# Altium schematic sheet Training_Stand.SchDoc
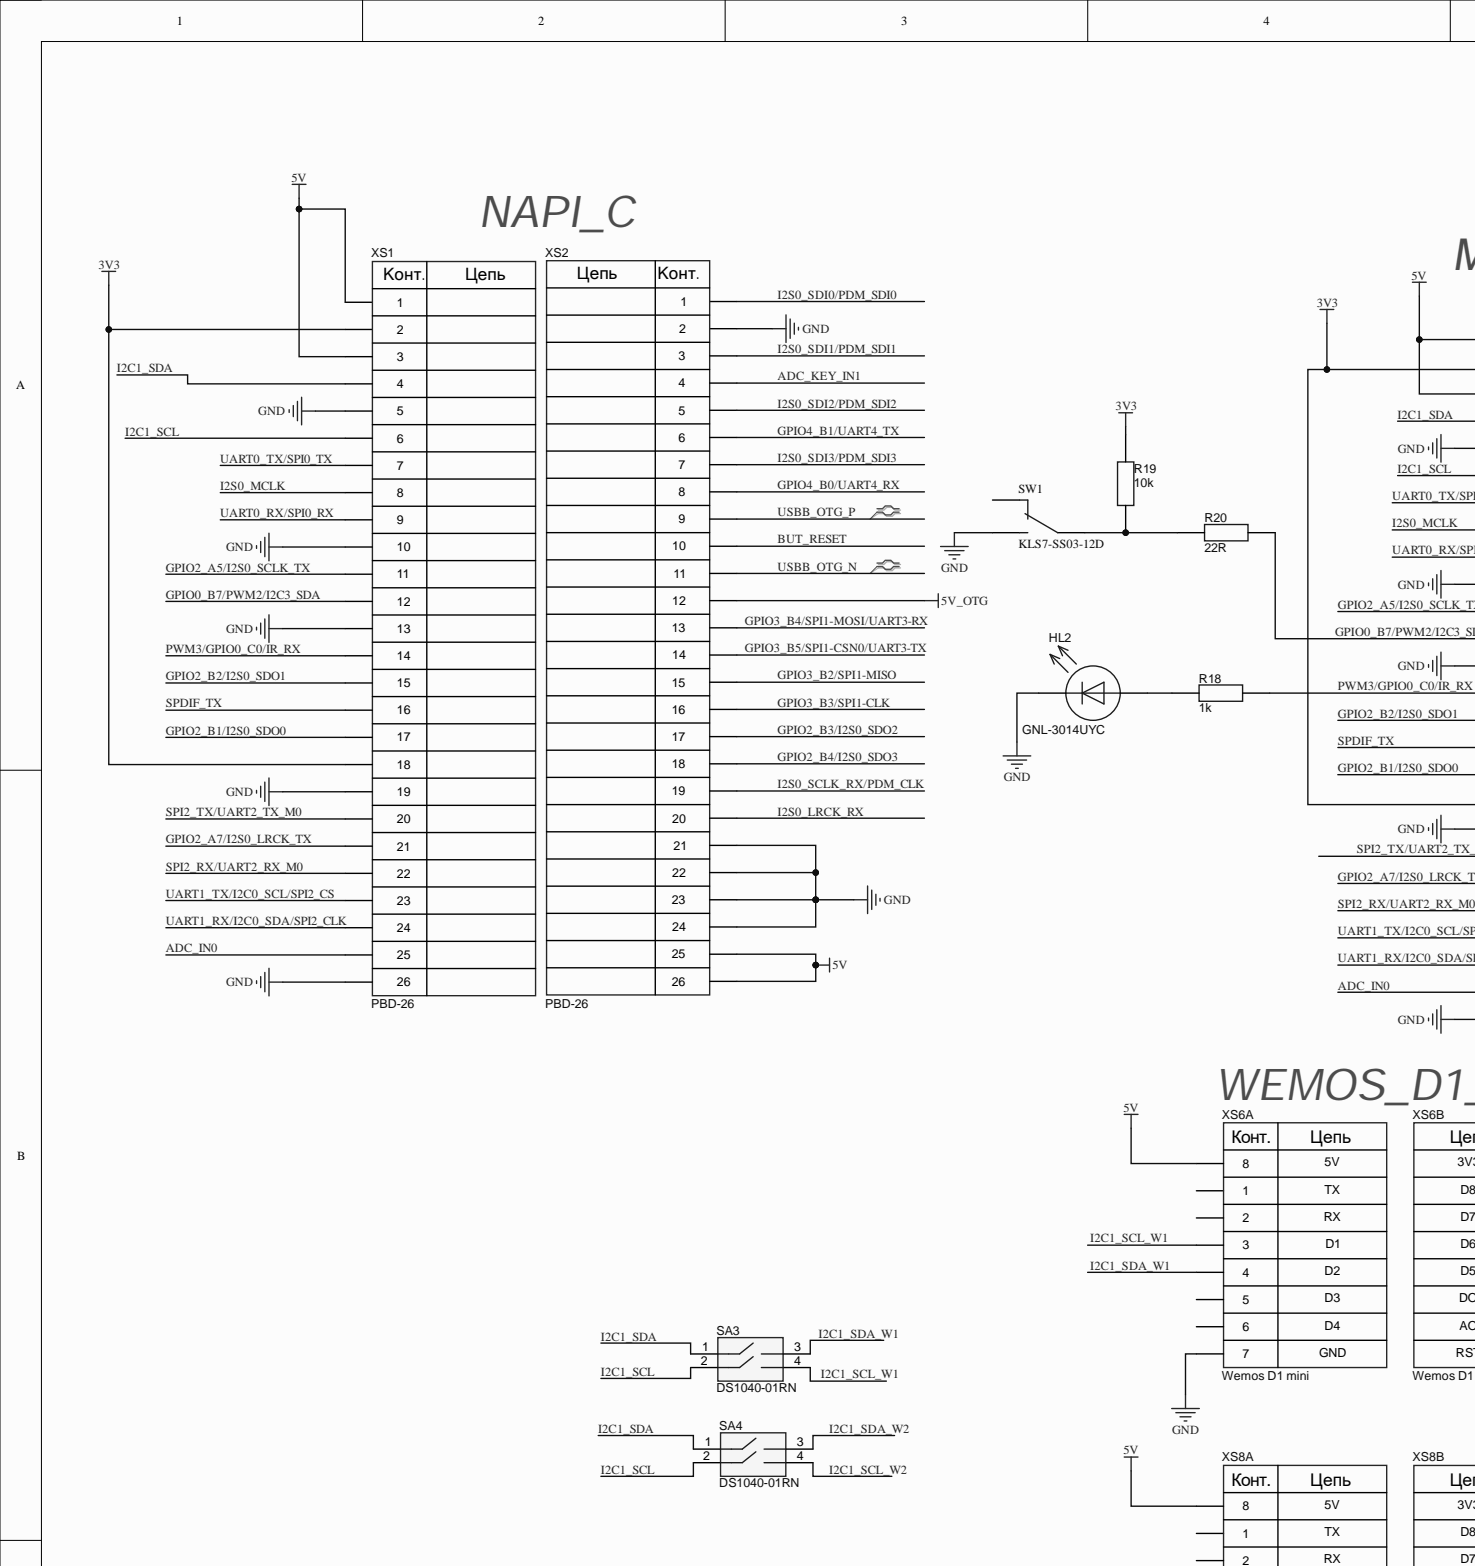
[diagram p0: Training_Stand.SchDoc, top left]
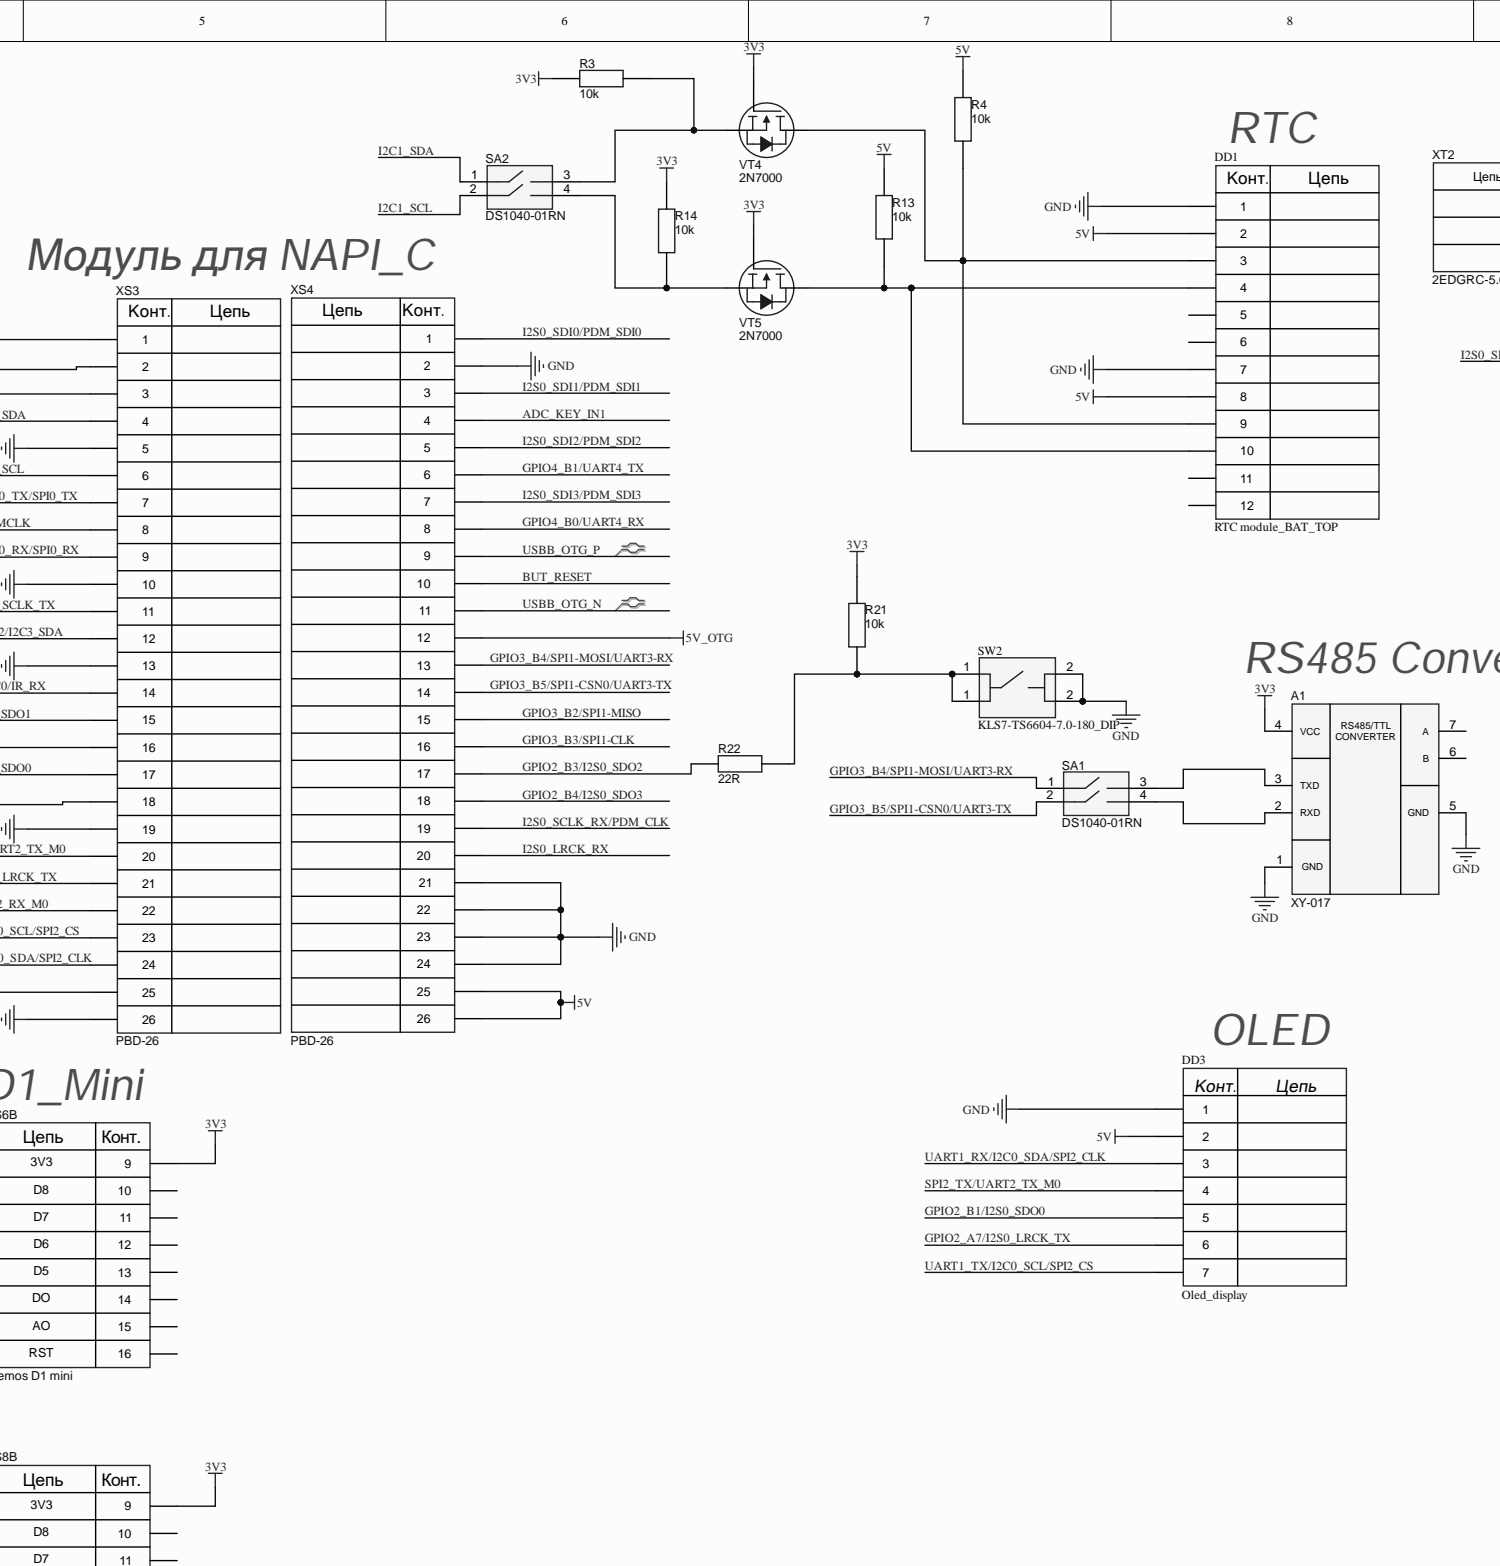
[diagram p1: Training_Stand.SchDoc, top centre]
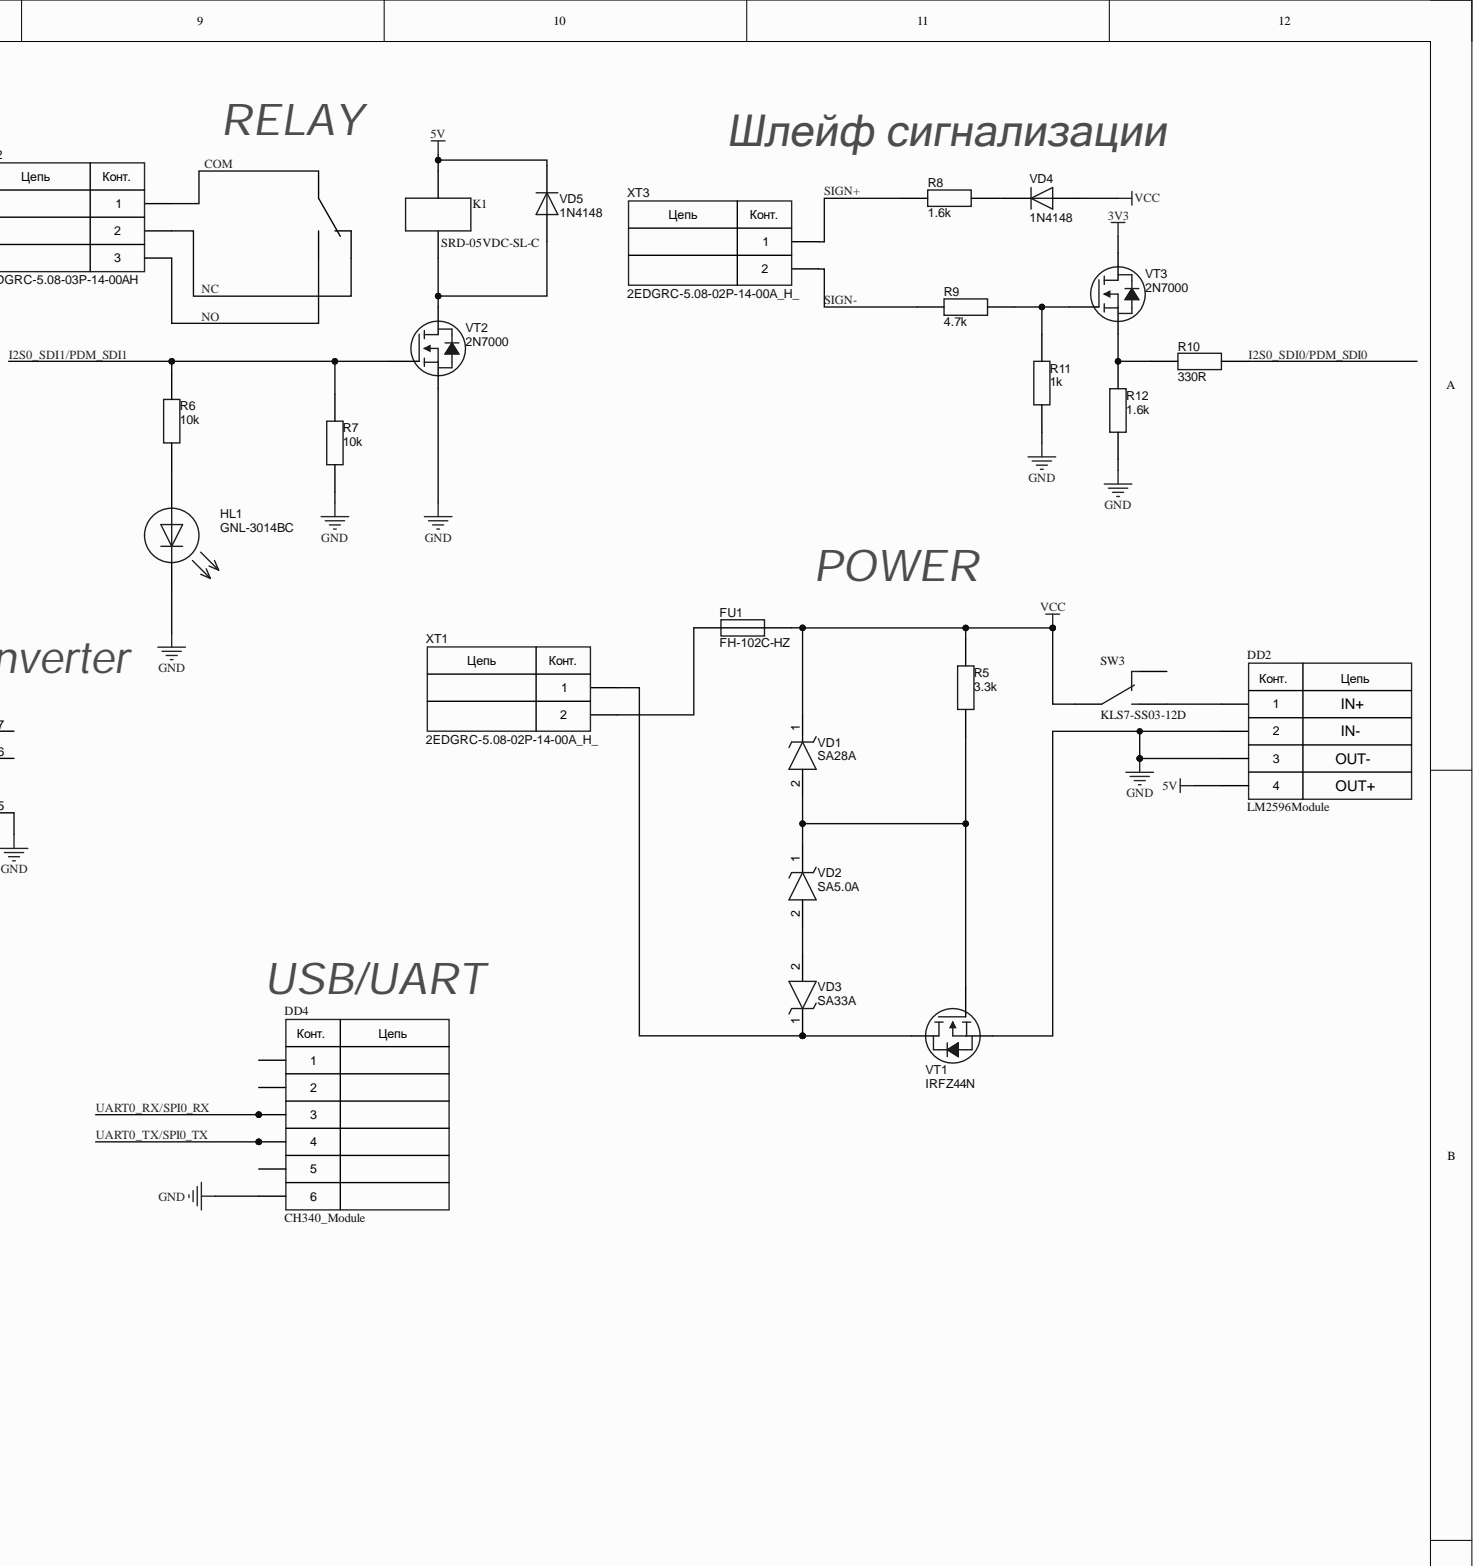
[diagram p2: Training_Stand.SchDoc, top right]
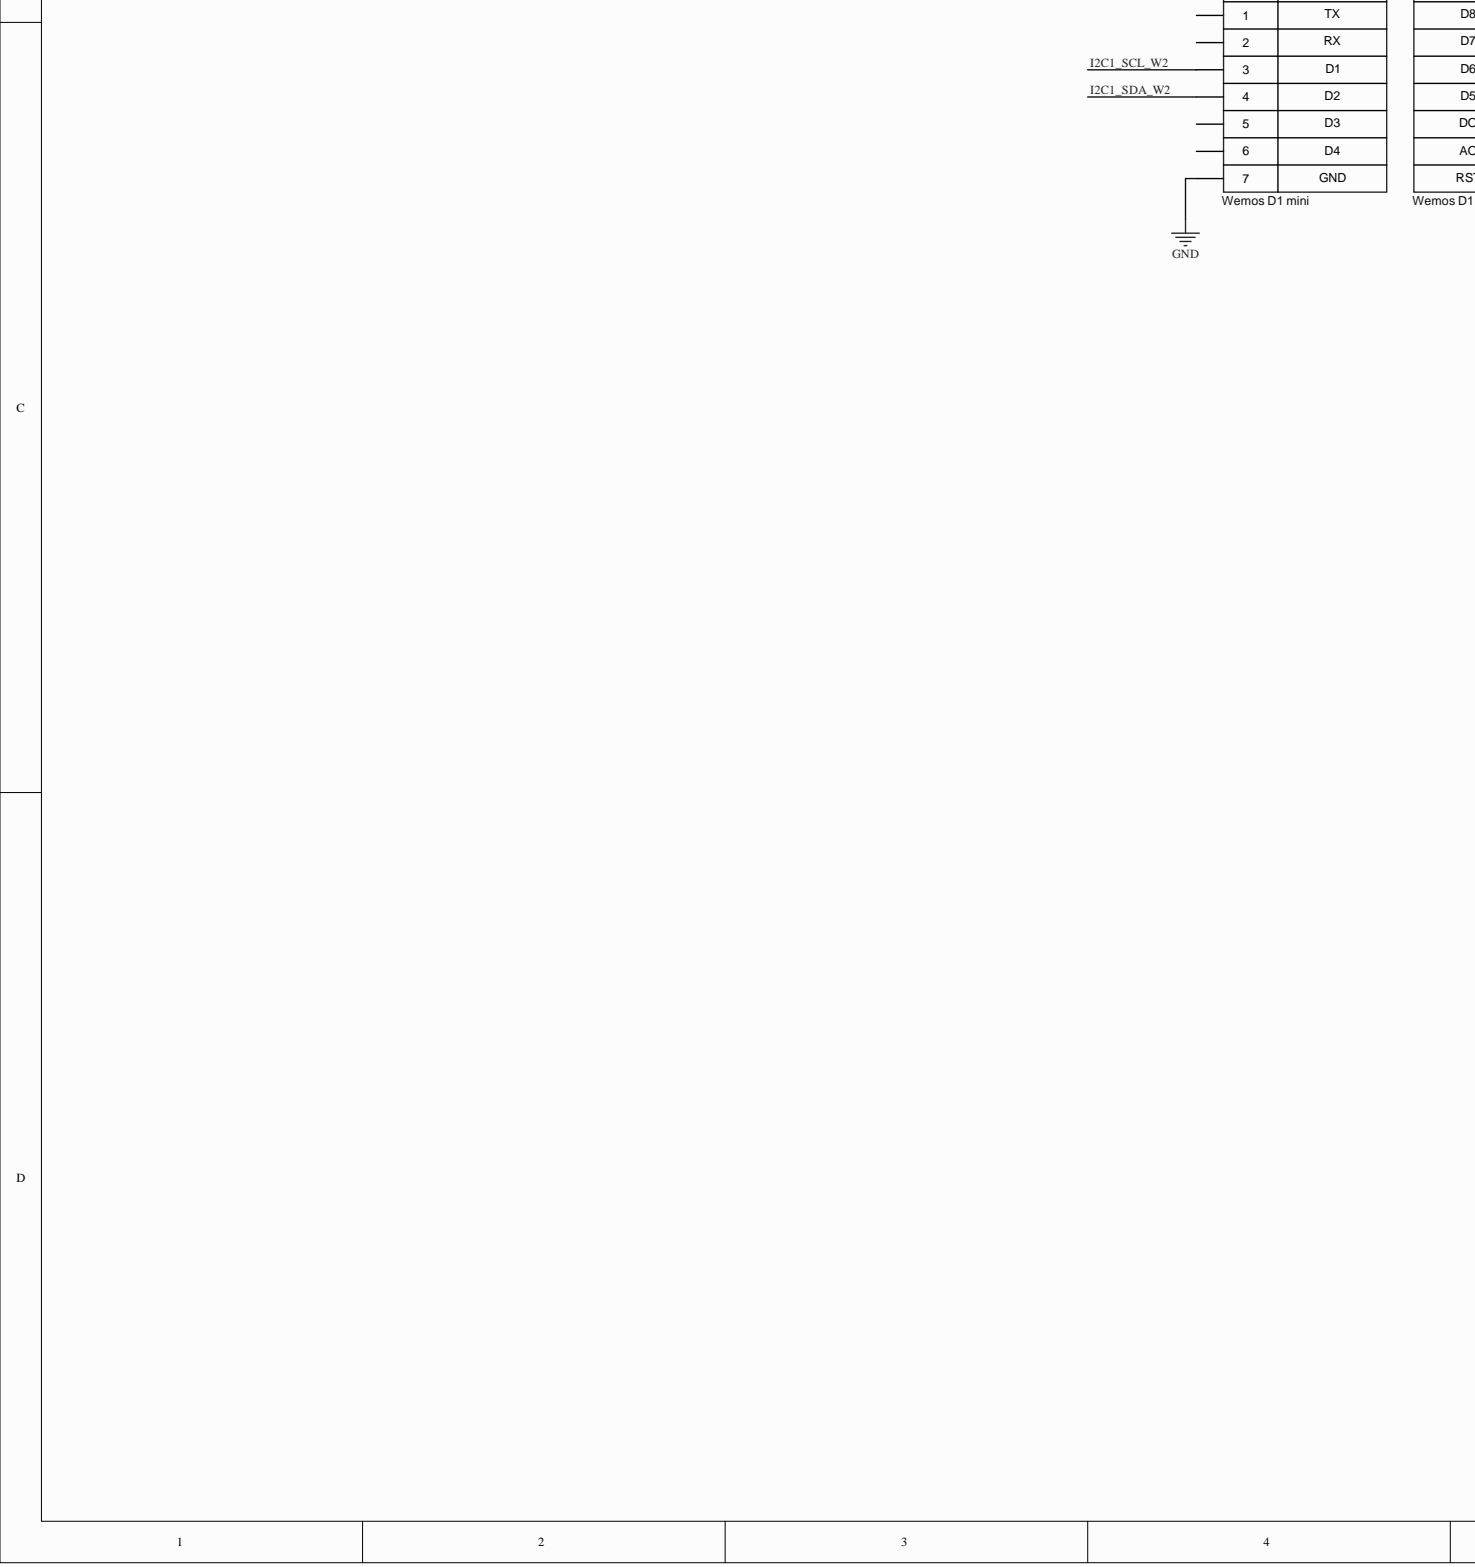
[diagram p3: Training_Stand.SchDoc, bottom left]
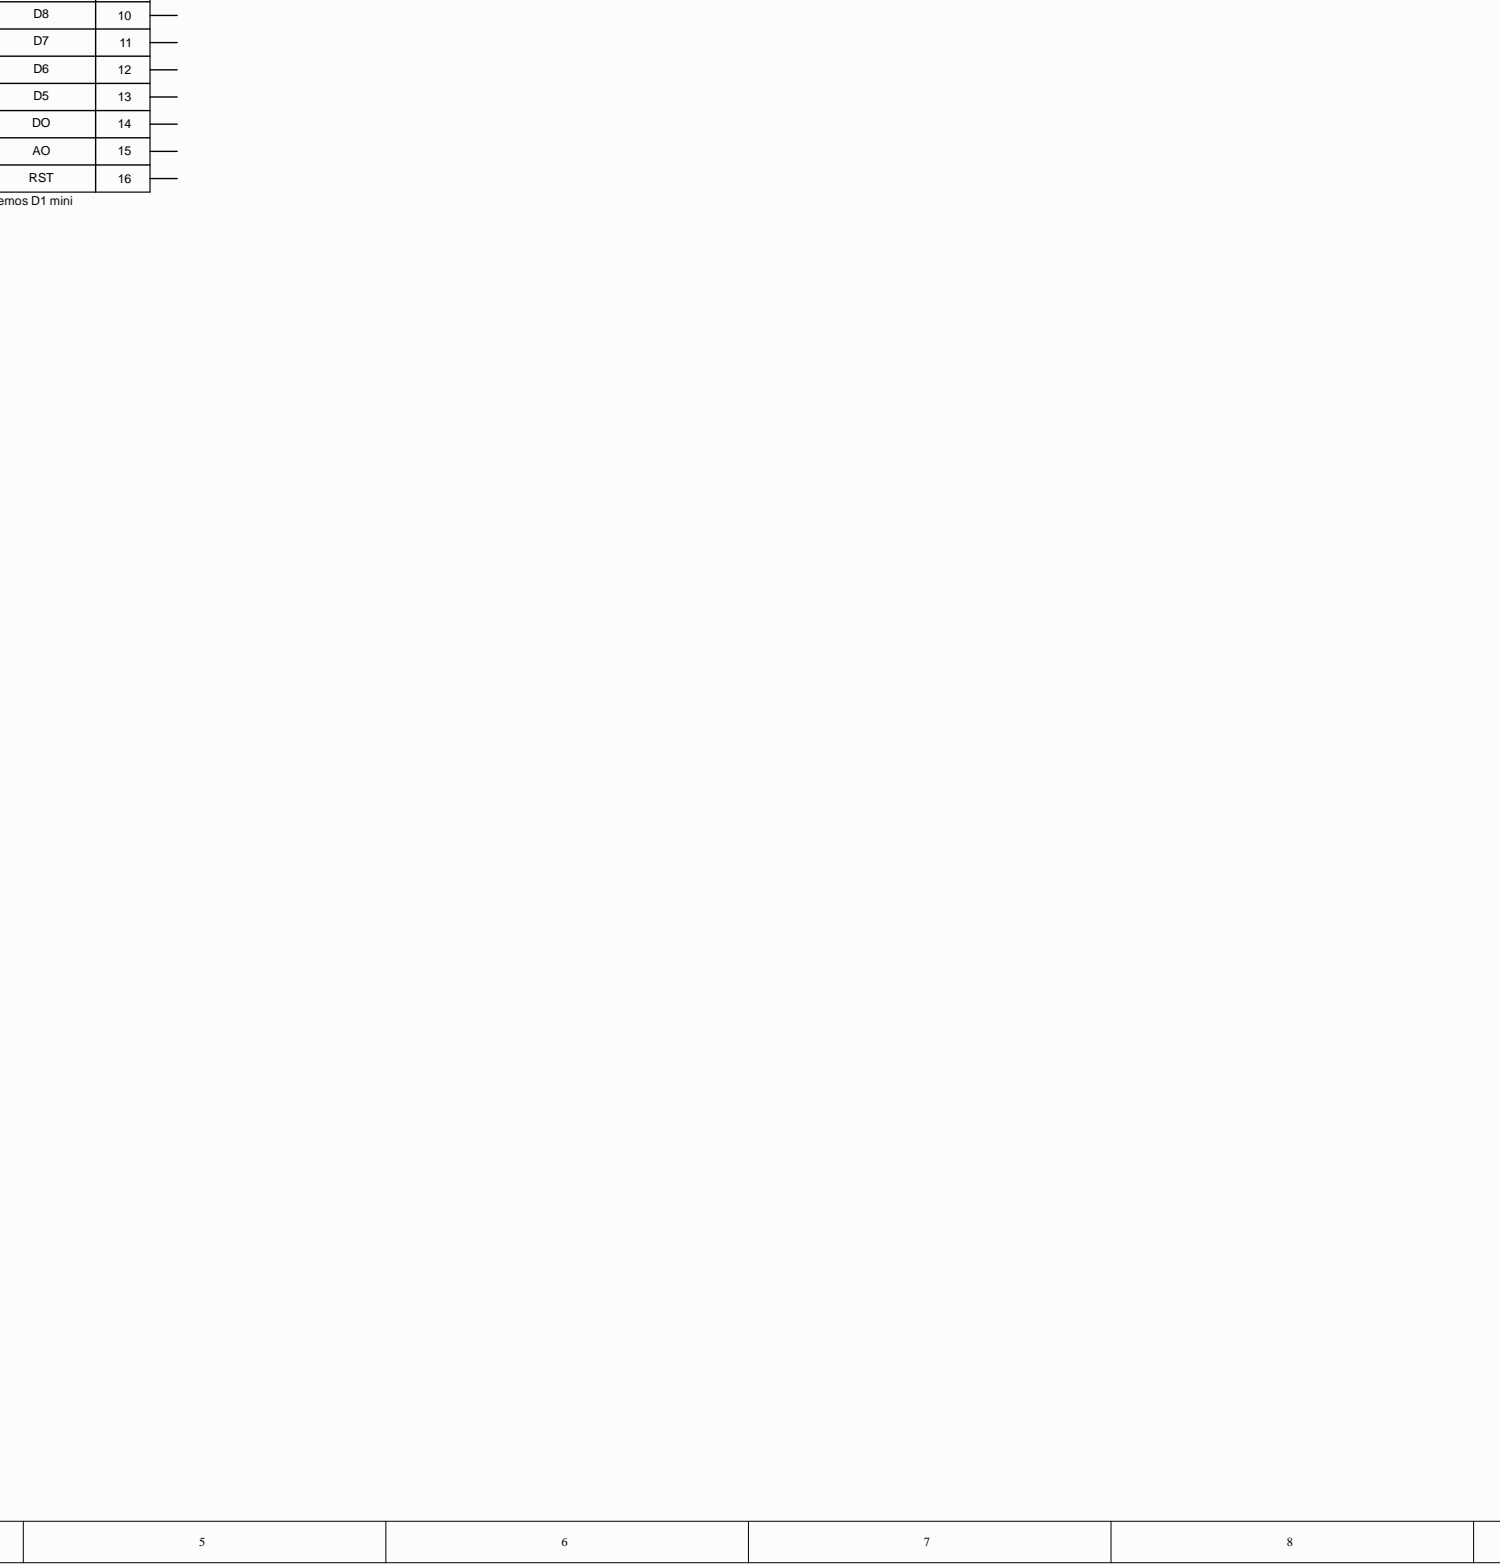
[diagram p4: Training_Stand.SchDoc, bottom centre]
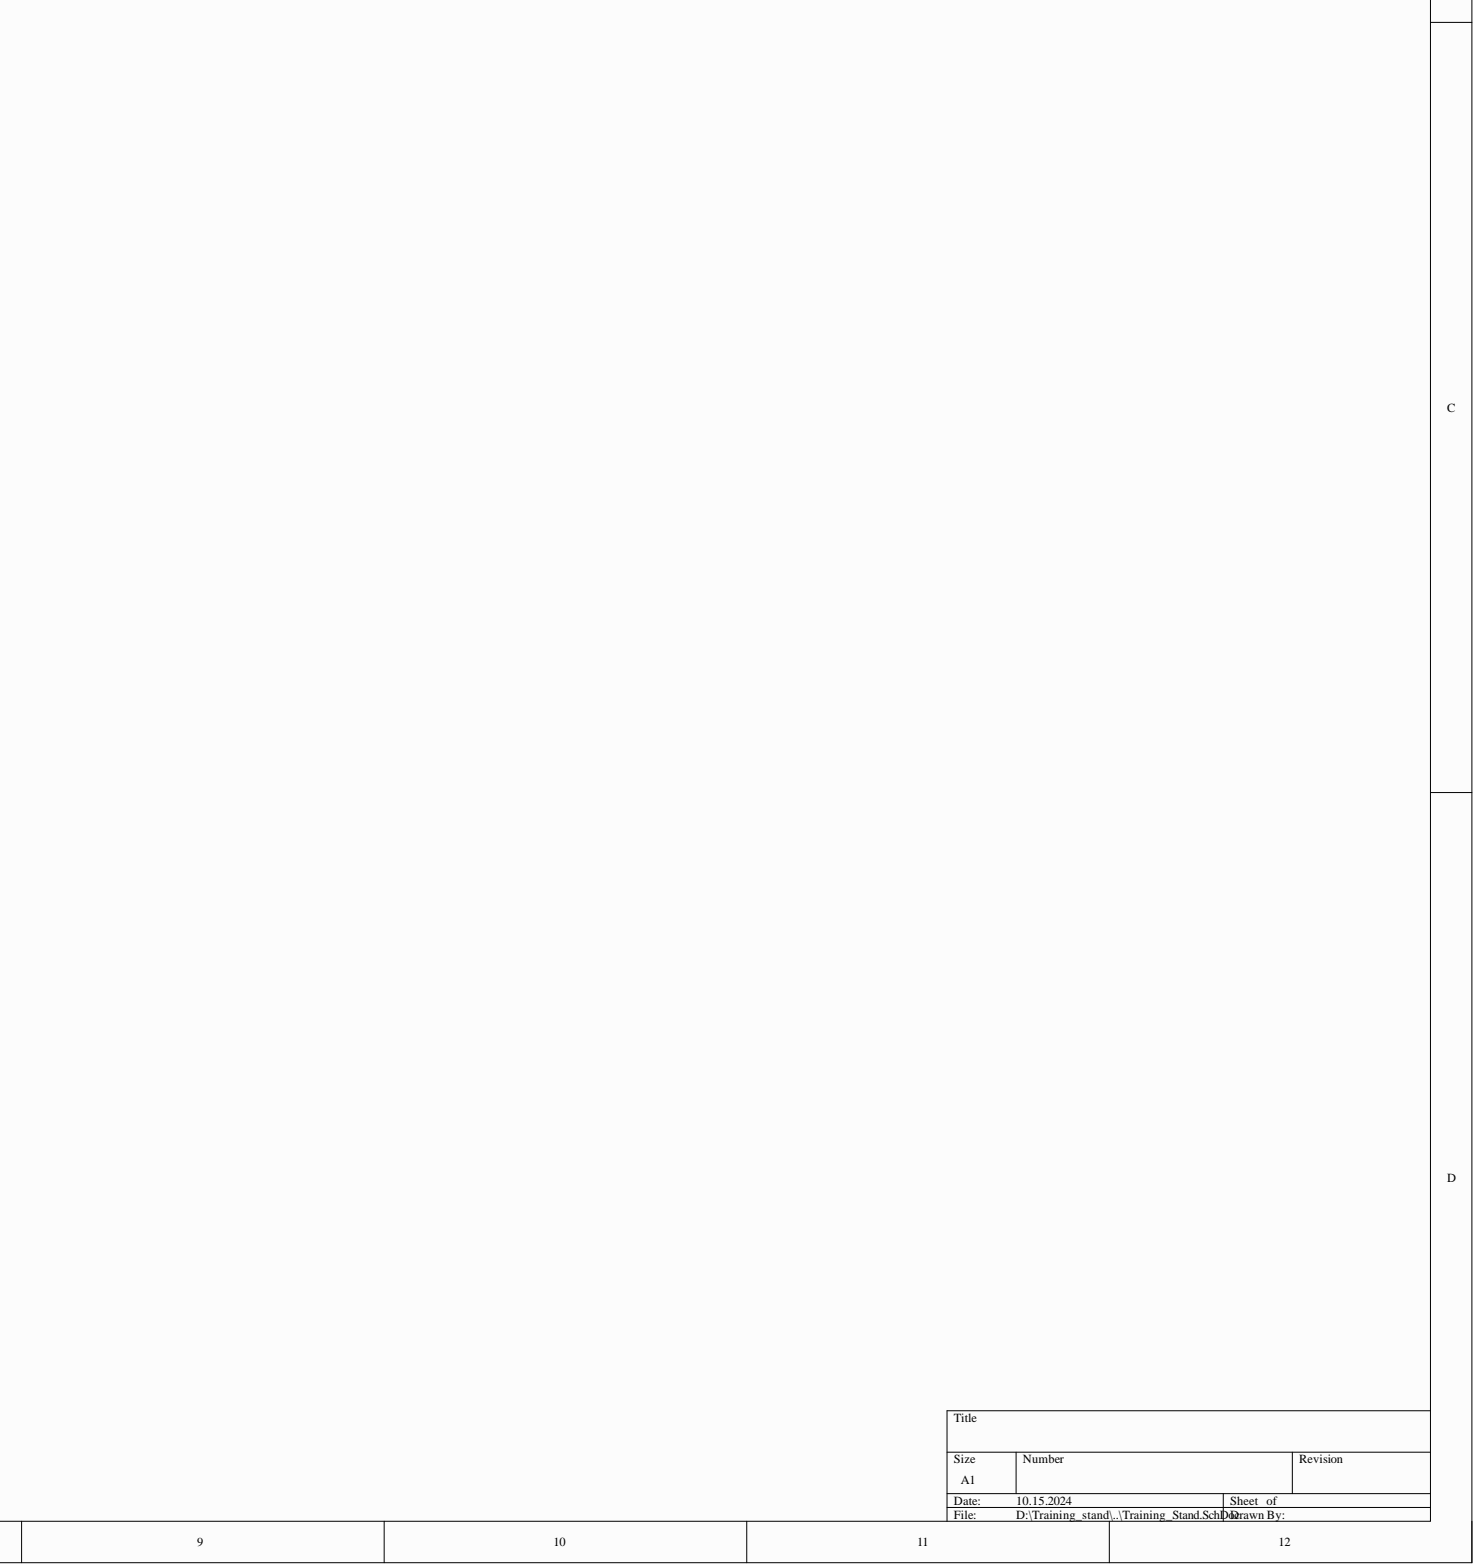
[diagram p5: Training_Stand.SchDoc, bottom right]

## Parts
A1  XY-017  [XY-017 RS485/TTL]
DD1  RTC module_BAT_TOP  [RTC module_BAT TOP]
DD2  LM2596Module  [LM2696 module]
DD3  Oled_display  [OLED_ARDUINO_SPI]
DD4  CH340_Module  [CH340 module]
FU1  FH-102C-HZ  [FH-102]
HL1  GNL-3014BC  [LED_Blue_dip]
HL2  GNL-3014UYC  [LED_Yellow_dip]
K1  SRD-05VDC-SL-C  Voltage: 5V  [SRD-05VDC-SL-C]
R3  10k  [RES_DIP]
R4  10k  [RES_DIP]
R5  3.3k  [RES_DIP]
R6  10k  [RES_DIP]
R7  10k  [RES_DIP]
R8  1.6k  [RES_DIP]
R9  4.7k  [RES_DIP]
R10  330R  [RES_DIP]
R11  1k  [RES_DIP]
R12  1.6k  [RES_DIP]
R13  10k  [RES_DIP]
R14  10k  [RES_DIP]
R18  1k  [RES_DIP]
R19  10k  [RES_DIP]
R20  22R  [RES_DIP]
R21  10k  [RES_DIP]
R22  22R  [RES_DIP]
SA1  DS1040-01RN  [DS1040-02N]
SA2  DS1040-01RN  [DS1040-02N]
SA3  DS1040-01RN  [DS1040-02N]
SA4  DS1040-01RN  [DS1040-02N]
SW1  KLS7-SS03-12D  [KLS7-SS03-12D02]
SW2  KLS7-TS6604-7.0-180_DIP  [Tact_SW_7.0]
SW3  KLS7-SS03-12D  [KLS7-SS03-12D02]
VD1  SA28A  [SA28A]
VD2  SA5.0A  [SA5.0]
VD3  SA33A  [SA33A]
VD4  1N4148  [DO-35(1n4148)]
VD5  1N4148  [DO-35(1n4148)]
VT1  IRFZ44N  [TO-220]
VT2  2N7000  [TO-92]
VT3  2N7000  [TO-92]
VT4  2N7000  [TO-92]
VT5  2N7000  [TO-92]
XS1  PBD-26  [PBD-26]
XS2  PBD-26  [PBD-26]
XS3  PBD-26  [PBD-26]
XS4  PBD-26  [PBD-26]
XS6  Wemos D1 mini  [Wemos D1 relay v2_PBD]  (parts A,B)
XS8  Wemos D1 mini  [Wemos D1 relay v2_PBD]  (parts A,B)
XT1  2EDGRC-5.08-02P-14-00A_H_  [2EDGRC-5.0-02P-14-00AH]
XT2  2EDGRС-5.08-03P-14-00AH  [2EDGRС-5.08-03P-14-00AH]
XT3  2EDGRC-5.08-02P-14-00A_H_  [2EDGRC-5.0-02P-14-00AH]

## Nets
3V3: A1.4(VCC) R3.2 R14.2 R19.2 R21.2 VT3.3 VT4.1 VT5.1 XS1.2 XS1.18 XS3.2 XS3.18 XS6.9 XS8.9
5V: DD1.2 DD1.8 DD2.4 DD3.2 K1.A2 R4.2 R13.2 VD5.1 XS1.1 XS1.3 XS2.25 XS2.26 XS3.1 XS3.3 XS4.25 XS4.26 XS6.8 XS8.8
5V_OTG: XS2.12 XS4.12
GND: A1.1(GND) A1.5(GND) DD1.1 DD1.7 DD2.2 DD2.3 DD3.1 DD4.6 HL1.2 HL2.2 R7.1 R11.1 R12.1 SW1.3 SW2.2 VT1.2 VT2.2 XS1.5 XS1.10 XS1.13 XS1.19 XS1.26 XS2.2 XS2.21 XS2.22 XS2.23 XS2.24 XS3.5 XS3.10 XS3.13 XS3.19 XS3.26 XS4.2 XS4.21 XS4.22 XS4.23 XS4.24 XS6.7 XS8.7
VCC: FU1.2 R5.2 SW3.2 VD1.1 VD4.2
ADC_IN0: XS1.25 XS3.25
ADC_KEY_IN1: XS2.4 XS4.4
BUT_RESET: XS2.10 XS4.10
COM: K1.COM XT2.1
GPIO0_B7/PWM2/I2C3_SDA: R20.2 XS1.12 XS3.12
GPIO2_A5/I2S0_SCLK_TX: XS1.11 XS3.11
GPIO2_A7/I2S0_LRCK_TX: DD3.6 XS1.21 XS3.21
GPIO2_B1/I2S0_SDO0: DD3.5 XS1.17 XS3.17
GPIO2_B2/I2S0_SDO1: XS1.15 XS3.15
GPIO2_B3/I2S0_SDO2: R22.1 XS2.17 XS4.17
GPIO2_B4/I2S0_SDO3: XS2.18 XS4.18
GPIO3_B2/SPI1-MISO: XS2.15 XS4.15
GPIO3_B3/SPI1-CLK: XS2.16 XS4.16
GPIO3_B4/SPI1-MOSI/UART3-RX: SA1.1 XS2.13 XS4.13
GPIO3_B5/SPI1-CSN0/UART3-TX: SA1.2 XS2.14 XS4.14
GPIO4_B0/UART4_RX: XS2.8 XS4.8
GPIO4_B1/UART4_TX: XS2.6 XS4.6
I2C1_SCL: SA2.2 SA3.2 SA4.2 XS1.6 XS3.6
I2C1_SCL_W1: SA3.4 XS6.3
I2C1_SCL_W2: SA4.4 XS8.3
I2C1_SDA: SA2.1 SA3.1 SA4.1 XS1.4 XS3.4
I2C1_SDA_W1: SA3.3 XS6.4
I2C1_SDA_W2: SA4.3 XS8.4
I2S0_LRCK_RX: XS2.20 XS4.20
I2S0_MCLK: XS1.8 XS3.8
I2S0_SCLK_RX/PDM_CLK: XS2.19 XS4.19
I2S0_SDI0/PDM_SDI0: R10.1 XS2.1 XS4.1
I2S0_SDI1/PDM_SDI1: R6.2 R7.2 VT2.1 XS2.3 XS4.3
I2S0_SDI2/PDM_SDI2: XS2.5 XS4.5
I2S0_SDI3/PDM_SDI3: XS2.7 XS4.7
NC: K1.NC XT2.2
NO: K1.NO XT2.3
PWM3/GPIO0_C0/IR_RX: R18.1 XS1.14 XS3.14
SIGN+: R8.1 XT3.1
SIGN-: R9.1 XT3.2
SPDIF_TX: XS1.16 XS3.16
SPI2_RX/UART2_RX_M0: XS1.22 XS3.22
SPI2_TX/UART2_TX_M0: DD3.4 XS1.20 XS3.20
UART0_RX/SPI0_RX: DD4.3 XS1.9 XS3.9
UART0_TX/SPI0_TX: DD4.4 XS1.7 XS3.7
UART1_RX/I2C0_SDA/SPI2_CLK: DD3.3 XS1.24 XS3.24
UART1_TX/I2C0_SCL/SPI2_CS: DD3.7 XS1.23 XS3.23
USBB_OTG_N: XS2.11 XS4.11
USBB_OTG_P: XS2.9 XS4.9
NetA1_2: A1.2(RXD) SA1.4
NetA1_3: A1.3(TXD) SA1.3
NetDD1_3: DD1.3 DD1.9 R4.1 VT4.3
NetDD1_4: DD1.4 DD1.10 R13.1 VT5.3
NetDD2_1: DD2.1 SW3.3
NetFU1_1: FU1.1 XT1.2
NetHL1_1: HL1.1 R6.1
NetHL2_1: HL2.1 R18.2
NetK1_A1: K1.A1 VD5.2 VT2.3
NetR3_1: R3.1 SA2.3 VT4.2
NetR5_1: R5.1 VD1.2 VD2.1 VT1.1
NetR8_2: R8.2 VD4.1
NetR9_2: R9.2 R11.2 VT3.1
NetR10_2: R10.2 R12.2 VT3.2
NetR14_1: R14.1 SA2.4 VT5.2
NetR19_1: R19.1 R20.1 SW1.2
NetR21_1: R21.1 R22.2 SW2.1
NetVD2_2: VD2.2 VD3.2
NetVD3_1: VD3.1 VT1.3 XT1.1

Not connected: A1 6(B) 7(A); DD1 5 6 11 12; DD4 1 2 5; SW1 1; SW3 1; XS6 1 2 5 6 10 11 12 13 14 15 16; XS8 1 2 5 6 10 11 12 13 14 15 16

## Library data of the parts
A1 = XY-017: RS485 to TTL converter; Current: 0.02A; Voltage: 5.5V; Case(Package): XY-017; Component Kind: Standard; ComponentLink1Description: Datasheet; HelpURL: https://www.chipdip.ru/product0/8021250854; Manufacturer_ID: XY-017; Library Name: Training_Stand.SchLib
DD3 = Oled_arduino_spi: Display; Number of contacts: 7; Pin pitch: 2.54mm; Component Kind: Standard; ComponentLink1Description: Datasheet; Manufacturer_ID: Oled_display; Number of rows: 1; Library Name: Training_Stand.SchLib
FU1 = FH-102C-HZ: Fuse 5A; Component Kind: Standard; ComponentLink1Description: Datasheet; ComponentLink1URL: file:///Z:/Shematic/Datasheet/0154003.pdf; Current: 5A; HelpURL: https://www.promelec.ru/product/429031/; Manufacturer_ID: FH-102C-HZ; Value: 5A; Library Name: Training_Stand.SchLib
HL1 = LED_Blue: LED blue DIP; Current: 20 mA; Voltage: 3.5 V; Case: DIP; Color: Blue; Component Kind: Standard; ComponentLink1Description: URL; Manufacturer_ID: GNL-3014BC; Library Name: Training_Stand.SchLib
HL2 = LED_Yellow: LED yellow DIP; Current: 20 mA; Voltage: 3.5 V; Case: DIP; Color: Yellow; Component Kind: Standard; ComponentLink1Description: URL; Manufacturer_ID: GNL-3014UYC; Library Name: Training_Stand.SchLib
K1 = SRD-05VDC-SL-C: Relay; Current: 10A; Component Kind: Standard; HelpURL: https://www.chipdip.ru/product0/8023333005; Manufacturer_ID: SRD-05VDC-SL-C; Library Name: Training_Stand.SchLib
R3-R14 R18-R22 = Res_DIP: Resistor; Case (Package): 1206; Component Kind: Standard; ComponentLink1Description: Datasheet; Library Name: Training_Stand.SchLib
  R3 R4 R6 R7 R13 R14 R19 R21: Value: 10k
  R5: Value: 3.3k
  R8 R12: Value: 1.6k
  R9: Value: 4.7k
  R10: Value: 330R
  R11 R18: Value: 1k
  R20 R22: Value: 22R
SA1-SA4 = DS1040-01RN: DIP switches; Current: 25mA; Voltage: 24V; Case (Package): DS1040-01RN; Component Kind: Standard; Manufacturer_ID: DS1040-01RN; URL: https://www.promelec.ru/product/515128/?utm_source=yandex&utm_medium=cpc&utm_campaign=tovar&yclid=3583169306453868543; Library Name: Training_Stand.SchLib
SW2 = KLS7-TS6604-7.0-180_DIP: Tactile Switches 3.5x2.9mm, Rectangular; Case(Package): 6x6mm; Current: 50mA; Voltage: 12V; Component Kind: Standard; ComponentLink1Description: Datasheet; HelpURL: https://www.chipdip.ru/product/kls7-ts6604-7.0-180-b-it-1102sb; Manufacturer_ID: KLS7-TS6604-7.0-180_DIP; Library Name: Training_Stand.SchLib
VD1 = SA28A: TVS Diode Bidirectional; Case (Package): DO-15; Voltage: 31V; Component Kind: Standard; ComponentLink1Description: Datasheet; ComponentLink1URL: file://192.168.16.5/share/Shematic/Datasheet/SMBJ30A.pdf; HelpURL: https://www.chipdip.ru/product/sa28a-sunmate; Manufacturer_ID: SA28A; Library Name: Training_Stand.SchLib
VD2 = SA5.0: TVS Diode Bidirectional; Case (Package): DO-15; Voltage: 6.4V; Component Kind: Standard; ComponentLink1Description: Datasheet; HelpURL: https://www.chipdip.ru/product/sa5.0a-sunmate; Manufacturer_ID: SA5.0A; Library Name: Training_Stand.SchLib
VD3 = SA33A: TVS Diode Bidirectional; Case (Package): DO-15; Voltage: 36V; Component Kind: Standard; ComponentLink1Description: Datasheet; ComponentLink1URL: file://192.168.16.5/share/Shematic/Datasheet/SMBJ30A.pdf; HelpURL: https://www.chipdip.ru/product0/8001983719; Manufacturer_ID: SA33A; Library Name: Training_Stand.SchLib
VD4 VD5 = 1N4148: Diode; Case (Package): DO-35; Current: 0.15A; Voltage: 75V; Component Kind: Standard; ComponentLink1Description: Datasheet; ComponentLink1URL: file://192.168.16.5/share/Shematic/Datasheet/LS4148-GS08.pdf; HelpURL: https://www.chipdip.ru/product/1n4148-yj; Manufacturer_ID: 1N4148; Library Name: Training_Stand.SchLib
VT1 = IRFZ44N: Transistor N-Channel Mosfet, 60V, 50A; Case(Package): TO-220; Component Kind: Standard; ComponentLink1Description: Datasheet; Current: 50A; HelpURL: https://www.chipdip.ru/product/irfz44n-umw; Manufacturer_ID: IRFZ44N; Voltage: 60V; Library Name: Training_Stand.SchLib
VT2-VT5 = 2N7000: Transistor N-Channel Mosfet, 60V, 0.2A; Case(Package): TO-220; Component Kind: Standard; ComponentLink1Description: Datasheet; Current: 0.2A; HelpURL: https://www.chipdip.ru/product/2n7000-jcet; Manufacturer_ID: 2N7000; Voltage: 60V; Library Name: Training_Stand.SchLib
XS1-XS4 = PBD-26: Connectors; Number of contacts: 26; Pin pitch: 2.54mm; Component Kind: Standard; ComponentLink1Description: Datasheet; ComponentLink1URL: file://192.168.16.5/share/Shematic/Datasheet/PBD-26.pdf; HelpURL: https://www.chipdip.ru/product/pbd-26; Manufacturer_ID: PBD-26; Number of rows: 2; Library Name: Training_Stand.SchLib
XS6 XS8 = Wemos D1 mini Relay_PBD: Connectors; Number of contacts: 16; Pin pitch: 2.54mm; Component Kind: Standard; ComponentLink1Description: Datasheet; Manufacturer_ID: Wemos D1 mini; Number of rows: 1; Library Name: Training_Stand.SchLib
XT1 XT3 = 2EDGRC-5.08-02P-14-00A_H_: Connectors; Number of contacts: 2; Pin pitch: 5.08; Component Kind: Standard; ComponentLink1Description: Datasheet; ComponentLink1URL: file://192.168.16.5/share/Shematic/Datasheet/2EDGRC-5.08-02P-14-00A_H_.pdf; HelpURL: https://www.chipdip.ru/product0/8452263737; Manufacturer_ID: 2EDGRC-5.08-02P-14-00A_H_; Number of rows: 1; Library Name: Training_Stand.SchLib
XT2 = 2EDGRС-5.08-03P-14-00AH: Connectors; Number of contacts: 3; Pin pitch: 5.08; Component Kind: Standard; ComponentLink1Description: New link; ComponentLink1URL: file://192.168.16.5/share/Shematic/Datasheet/15EDGRC-3.5-03P-14-00AH.pdf; HelpURL: https://www.chipdip.ru/product0/8027122664; Manufacturer_ID: 2EDGRС-5.08-03P-14-00AH; Number of rows: 1; Library Name: Training_Stand.SchLib
DD1 = RTC module_BAT_TOP: Component Kind: Standard; Library Name: Training_Stand.SchLib
DD2 = LM2596Module: Component Kind: Standard; Library Name: Training_Stand.SchLib
DD4 = CH340_Module: Component Kind: Standard; Library Name: Training_Stand.SchLib
SW1 SW3 = KLS7-SS03-12D: Component Kind: Standard; Manufacturer_ID: KLS7-SS03-12D; Library Name: Training_Stand.SchLib
